# Altium schematic sheet MeEvKit.SchDoc
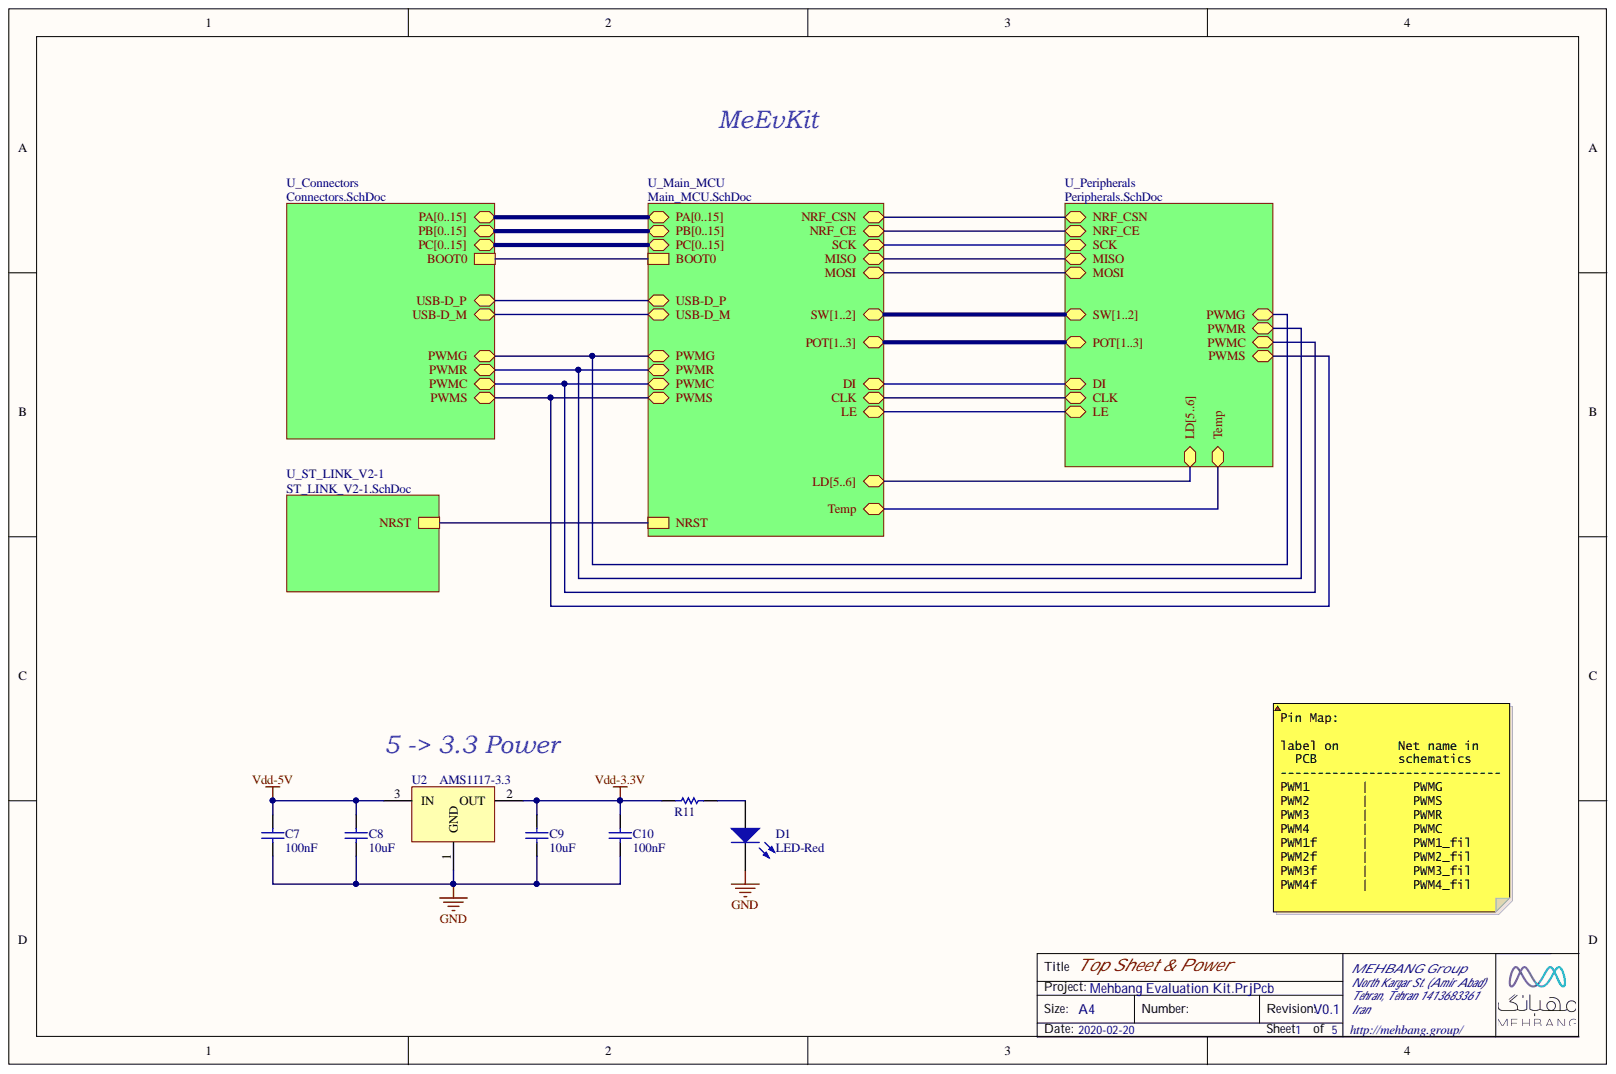
[diagram p0: MeEvKit.SchDoc]

## Parts
C7  100nF  [0805-Cap]
C8  10uF  [0805-Cap]
C9  10uF  [0805-Cap]
C10  100nF  [0805-Cap]
D1  LED-Red  [0805-LED]
R11  2.7K  [0805-Res]  (value not drawn)
U2  AMS1117-3.3  [AMS1117]

## Nets
GND: C7.1 C8.1 C9.1 C10.2 D1.2 U2.1
Vdd-3.3V: C9.2 C10.1 R11.2 U2.2
Vdd-5V: C7.2 C8.2 U2.3
NetD1_1: D1.1 R11.1
BOOT0:
CLK:
DI:
LE:
MISO:
MOSI:
N00146:
NRF_CE:
NRF_CSN:
NRST:
PWMC:
PWMG:
PWMR:
PWMS:
SCK:
Temp:
USB-D_M:
USB-D_P:

## Library data of the parts
C7-C10 = Cap: Capacitor; Component Kind: Standard; LatestRevisionDate: 17-Jul-2002; LatestRevisionNote: Re-released for DXP Platform.; Library Name: DaEvKit-LIB.IntLib; PackageDescription: Radial Cap, Thru-Hole; 2 Leads; 0.3 in Pin Spacing; PackageReference: RAD-0.3; Published: 8-Jun-2000; Publisher: Altium Limited
D1 = LED: Typical RED, GREEN, YELLOW, AMBER GaAs LED; Component Kind: Standard; LatestRevisionDate: 17-Jul-2002; LatestRevisionNote: Re-released for DXP Platform.; Library Name: DaEvKit-LIB.IntLib; PackageDescription: SM LED; 2 Flat Leads; PackageReference: 3.2X1.6X1.1; PackageVersion: Feb-2002; Published: 8-Jun-2000; Publisher: Altium Limited
R11 = Res: Resistor; Code_IPC: 2012 [0805]; Component Kind: Standard; ComponentLink1Description: Manufacturer Link; ComponentLink1URL: http://www.panasonic.com/industrial/components/resistive_products.htm; ComponentLink2Description: Datasheet; ComponentLink2URL: http://www.panasonic.com/industrial/components/pdf/AOF0000CE1.pdf; LatestRevisionNote: Silkscreen size reduced.; Library Name: DaEvKit-LIB.IntLib; Publisher: Altium Limited
U2 = regulator: Component Kind: Standard; Library Name: DaEvKit-LIB.IntLib
